# Altium schematic sheet HeartSchem.SchDoc(HeartSchem)
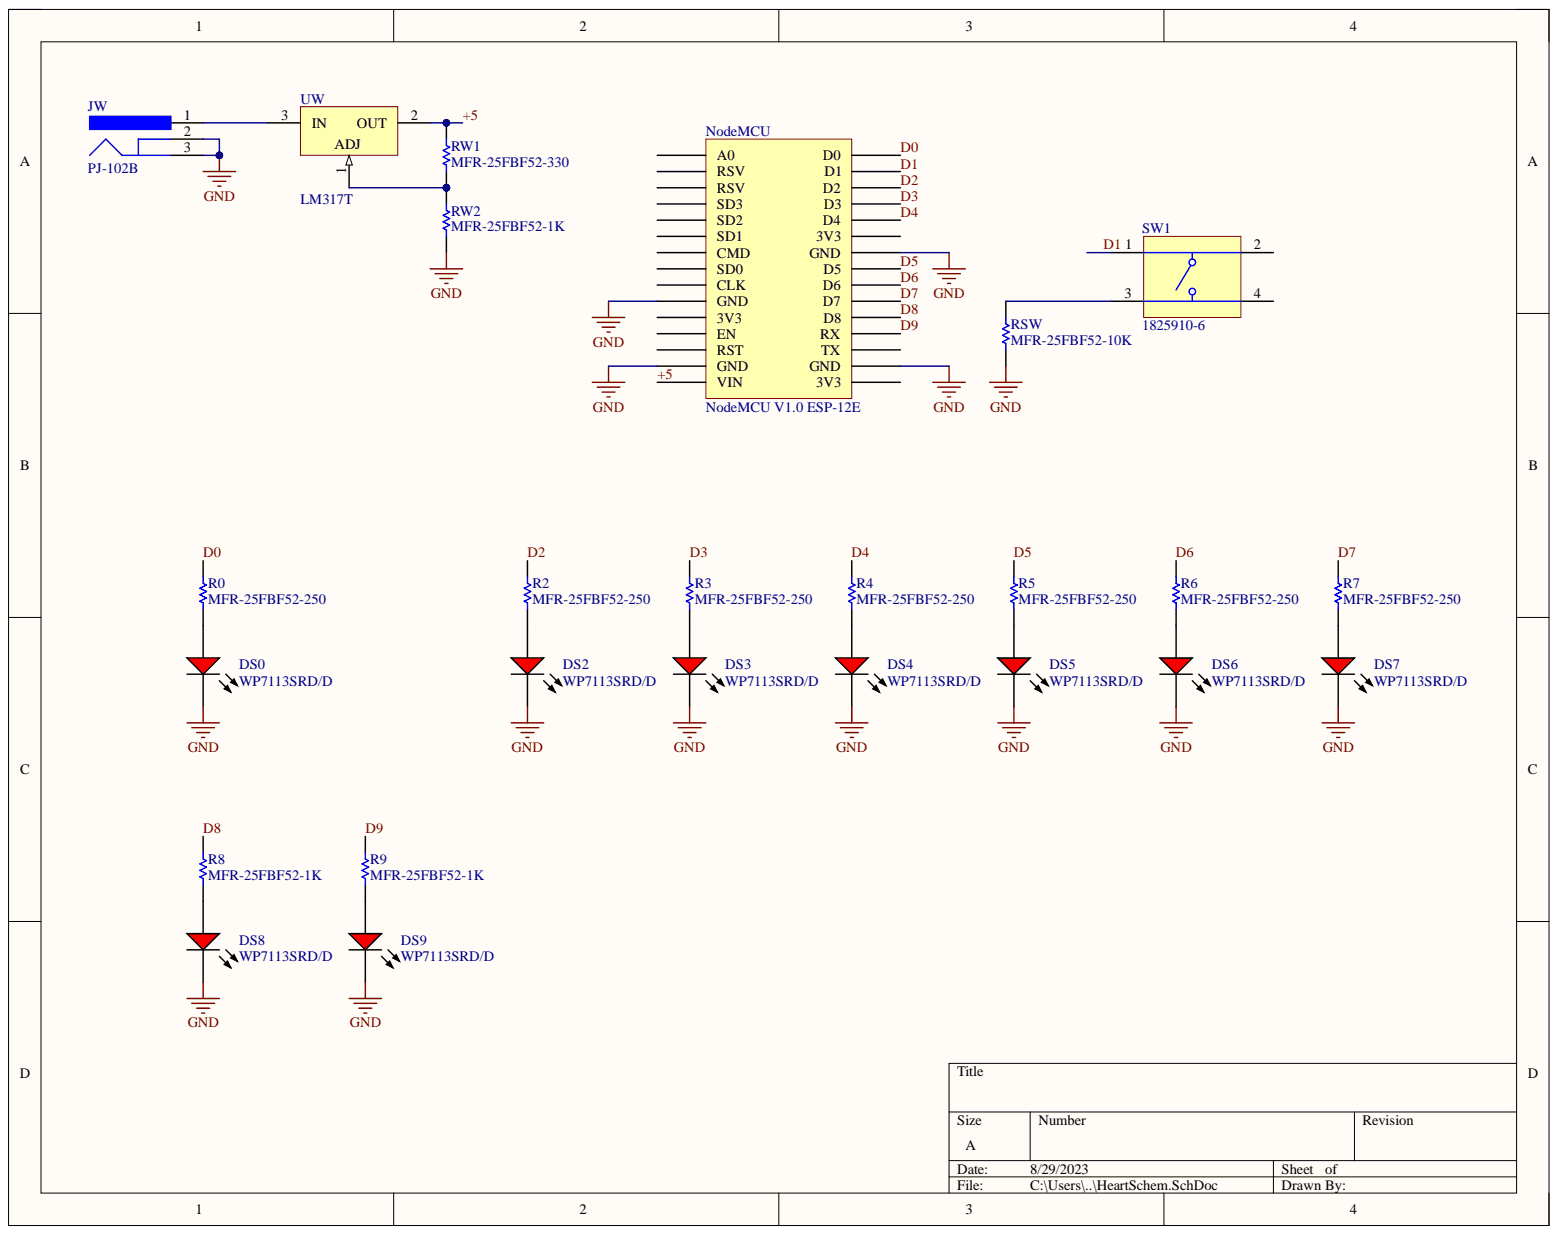
[diagram p0: HeartSchem.SchDoc]

## Parts
DS0  WP7113SRD/D  [KING-WP7113SRDD_V]
DS2  WP7113SRD/D  [KING-WP7113SRDD_V]
DS3  WP7113SRD/D  [KING-WP7113SRDD_V]
DS4  WP7113SRD/D  [KING-WP7113SRDD_V]
DS5  WP7113SRD/D  [KING-WP7113SRDD_V]
DS6  WP7113SRD/D  [KING-WP7113SRDD_V]
DS7  WP7113SRD/D  [KING-WP7113SRDD_V]
DS8  WP7113SRD/D  [KING-WP7113SRDD_V]
DS9  WP7113SRD/D  [KING-WP7113SRDD_V]
JW  PJ-102B  [CUI-PJ-102B_V]
NodeMCU  NodeMCU V1.0 ESP-12E  [NodeMCU V1.0 ESP-12E]
R0  MFR-25FBF52-250  [RESA60-630X240]
R2  MFR-25FBF52-250  [RESA60-630X240]
R3  MFR-25FBF52-250  [RESA60-630X240]
R4  MFR-25FBF52-250  [RESA60-630X240]
R5  MFR-25FBF52-250  [RESA60-630X240]
R6  MFR-25FBF52-250  [RESA60-630X240]
R7  MFR-25FBF52-250  [RESA60-630X240]
R8  MFR-25FBF52-1K  [RESA60-630X240]
R9  MFR-25FBF52-1K  [RESA60-630X240]
RSW  MFR-25FBF52-10K  [RESA60-630X240]
RW1  MFR-25FBF52-330  [RESA60-630X240]
RW2  MFR-25FBF52-1K  [RESA60-630X240]
SW1  1825910-6  [TECO-1825910-6_V]
UW  LM317T  [TO-220]

## Nets
GND: DS0.2 DS2.2 DS3.2 DS4.2 DS5.2 DS6.2 DS7.2 DS8.2 DS9.2 JW.2 JW.3 NodeMCU.GND RSW.2 RW2.2
+5: NodeMCU.VIN RW1.1 UW.2
D0: NodeMCU.D0 R0.1
D1: NodeMCU.D1 SW1.1
D2: NodeMCU.D2 R2.1
D3: NodeMCU.D3 R3.1
D4: NodeMCU.D4 R4.1
D5: NodeMCU.D5 R5.1
D6: NodeMCU.D6 R6.1
D7: NodeMCU.D7 R7.1
D8: NodeMCU.D8 R8.1
D9: NodeMCU.RX R9.1
NetDS0_1: DS0.1 R0.2
NetDS2_1: DS2.1 R2.2
NetDS3_1: DS3.1 R3.2
NetDS4_1: DS4.1 R4.2
NetDS5_1: DS5.1 R5.2
NetDS6_1: DS6.1 R6.2
NetDS7_1: DS7.1 R7.2
NetDS8_1: DS8.1 R8.2
NetDS9_1: DS9.1 R9.2
NetJW_1: JW.1 UW.3
NetRSW_1: RSW.1 SW1.3
NetRW1_2: RW1.2 RW2.1 UW.1

Not connected: NodeMCU 3V3 A0 CLK CMD EN RST RSV SD0 SD1 SD2 SD3 TX; SW1 2 4

## Library data of the parts
DS0 DS2-DS9 = CMP-007-00033-2: The Super Bright Red Source Color Device, 1.85 V, -40 to 85 degC, 2-Pin THD, RoHS, Tape and Reel; Manufacturer: Kingbright; Forward Voltage: 1.85V; Lens Style: Diffused; Luminous Intensity: 300mcd; Viewing Angle: 30degrees; Case/Package: Radial; Colour: Red; Component Kind: Standard; Datasheet URL: http://www.kingbrightusa.com/images/catalog/SPEC/WP7113SRD-D.pdf; Datasheet Version: Rev. 6A, 07/2016; Illumination Colour: Red; Mounting Technology: TH; Nominal Vf: 1.85V; Package Description: 2-Pin Through Hole Device, Dia 5.9 mm, Body Length 8.6 mm; Package Quantity: 500; Package Reference: WP7113SRDD; Packaging: Bulk; RoHS Compliant: True; Library Name: Christian Cipolletta's Workspace
JW = CMP-2000-06900-1: Through Hole Right Angle DC Power Jack, 2.5 A, 2.5 mm Center Pin, 3 Position, -25 to 85 degC, RoHS, Bulk; Manufacturer: CUIInc.; Brand: CUI; Current Rating: 2.5A; Inside Contact Diameter: 2.5mm; Insulation Resistance: 100MOhms; Outside Contact Diameter: 6.5mm; Voltage Rating: 24V; Component Kind: Standard; Connector Type: DCPowerJack; Contact Gender: Male; Contact Material: Copper; Contact Plating: Nickel; Datasheet URL: http://www.cui.com/product/resource/pj-102b.pdf; Datasheet Version: Rev. 1.03, 04/2016; Factory Pack Quantity: 280; Flammability Rating: UL94V-0; Housing Material: PolybutyleneTerephthalate(PBT); Library Name: Altium Content Vault; Manufacturer URL: http://www.cui.com/; Mounting Angle: Right; Mounting Style: ThroughHole; Mounting Technology: ThroughHole; Operating Temperature Range: -25Cto+85C; Package Description: 3-Pin Through Hole Device, Body 14.4 x 9 mm, Height 11 mm; Package Reference: PJ-102B; Packaging: Bulk; Product: Jacks; Product Category: DCPowerConnectors; RoHS: True; Termination Style: ThroughHole
NodeMCU = NodeMCU V1.0 ESP-12E: NodeMCU V1.0 ESP8266 (ESP-12E); Component Kind: Standard; Library Name: nodemcu.IntLib
R0 R2-R9 RSW RW1 RW2 = CMP-1659-00075-1: Axial Resistor, 1 KOhm, +/- 1%, 250 mW, -55 to 155 degC, 2-Pin THD, RoHS, Bulk; Power Rating: 250 mW; Resistance: 10 kohm; Voltage Rating: 250 V; Case\Package: Axial; Component Kind: Standard; ComponentLink1Description: Manufacturer URL; ComponentLink1URL: http://www.yageo.com/; ComponentLink2Description: Datasheet; ComponentLink2URL: http://www.yageo.com/documents/recent/Yageo%20LR_MFR_2013.pdf; DatasheetVersion: Apr-13; Length: 0.248 inch; Library Name: Altium Content Vault; Max Operating Temperature: 155 degC; Min Operating Temperature: -55 degC; Package Quantity: 200; PackageDescription: 2-Pin Axial Lead, Dia 2.4 mm, Body Length 6.3 mm; PackageReference: MFR-25; Packaging: Bulk; RoHSCompliant: true; Tolerance: 1%
SW1 = CMP-1684-00021-1: Tact Switch, SPST-NO, 0.05 A, -35 to 85 degC, 4-Pin THD, RoHS, Bulk; Manufacturer: TE Connectivity; Actuator Color: Black; Actuator Length: 1.4mm; Actuator Material: PolybutyleneTerephthalate; Actuator Style: RoundButton; Body Orientation: Straight; Bushing Type: Unthreaded; Contact Rating @ Voltage: 0.05A@24VDC; Dielectric Strength: 500VAC; Electrical Life: 100000Cycles; Function: MomentaryContact; Illumination: N/R; Maximum Contact Resistance: 100mOhm; Maximum DC Voltage Rating: 24V; Pole Throw Configuration: SPST; Switch Configuration: OFF(ON); Base Material: N/A; Component Kind: Standard; Contact Material: CopperAlloy; Contact Plating: Silver; Datasheet URL: http://www.te.com/commerce/DocumentDelivery/DDEController?Action=showdoc&DocId=Customer+Drawing%7F1825910%7FC9%7Fpdf%7FEnglish%7FENG_CD_1825910_C9.pdf%7F1825910-6; Datasheet Version: Rev. C9; Dimension: 5.99x5mm; Family: 1825910; Housing Material: N/A; Library Name: Altium Content Vault; Manufacturer URL: http://www.te.com; Maximum AC Voltage Rating: N/A; Mechanical Life: 100000Cycles; Minimum Insulation Resistance: 100MOhm; Mounting: ThroughHole; Operating Temperature: -35to85C; Package Description: 4-Pin Through Hole Device, Body 5.99 x 5.99 mm, Pitch 6.5 mm; Package Reference: THD; Packaging: N/A; Part No: 1825910-6; Product Weight: N/A; RoHS: Yes; Seal Description: N/A; Seal Location: N/A; Terminal Type: PCPins; Thread Size: N/R
UW = CMP-0244-00444-1: 1.5A, 1.2V to 37V Adjustable Voltage Regulator, 3-Pin TO-220; Component Kind: Standard; ComponentLink1Description: Manufacturer URL; ComponentLink1URL: http://www.st.com; ComponentLink2Description: Datasheet; ComponentLink2URL: http://www.st.com/internet/com/TECHNICAL_RESOURCES/TECHNICAL_LITERATURE/DATASHEET/CD00000455.pdf; ComponentLink3Description: Package Specification; ComponentLink3URL: http://www.st.com/internet/com/TECHNICAL_RESOURCES/TECHNICAL_LITERATURE/PACKAGE_INFORMATION/CD00004649.pdf; DatasheetVersion: 1/11/2011; Library Name: Altium Content Vault; PackageDescription: TO, Thru-Hole, Vertical, Heatsink Mounted; 3 In-Line Leads; Pitch 2.54 mm; PackageReference: TO-220; PackageVersion: 2011
